# Altium schematic sheet esp-neopixel-driver_1_Main.SchDoc(esp-neopixel-driver_1_Main)
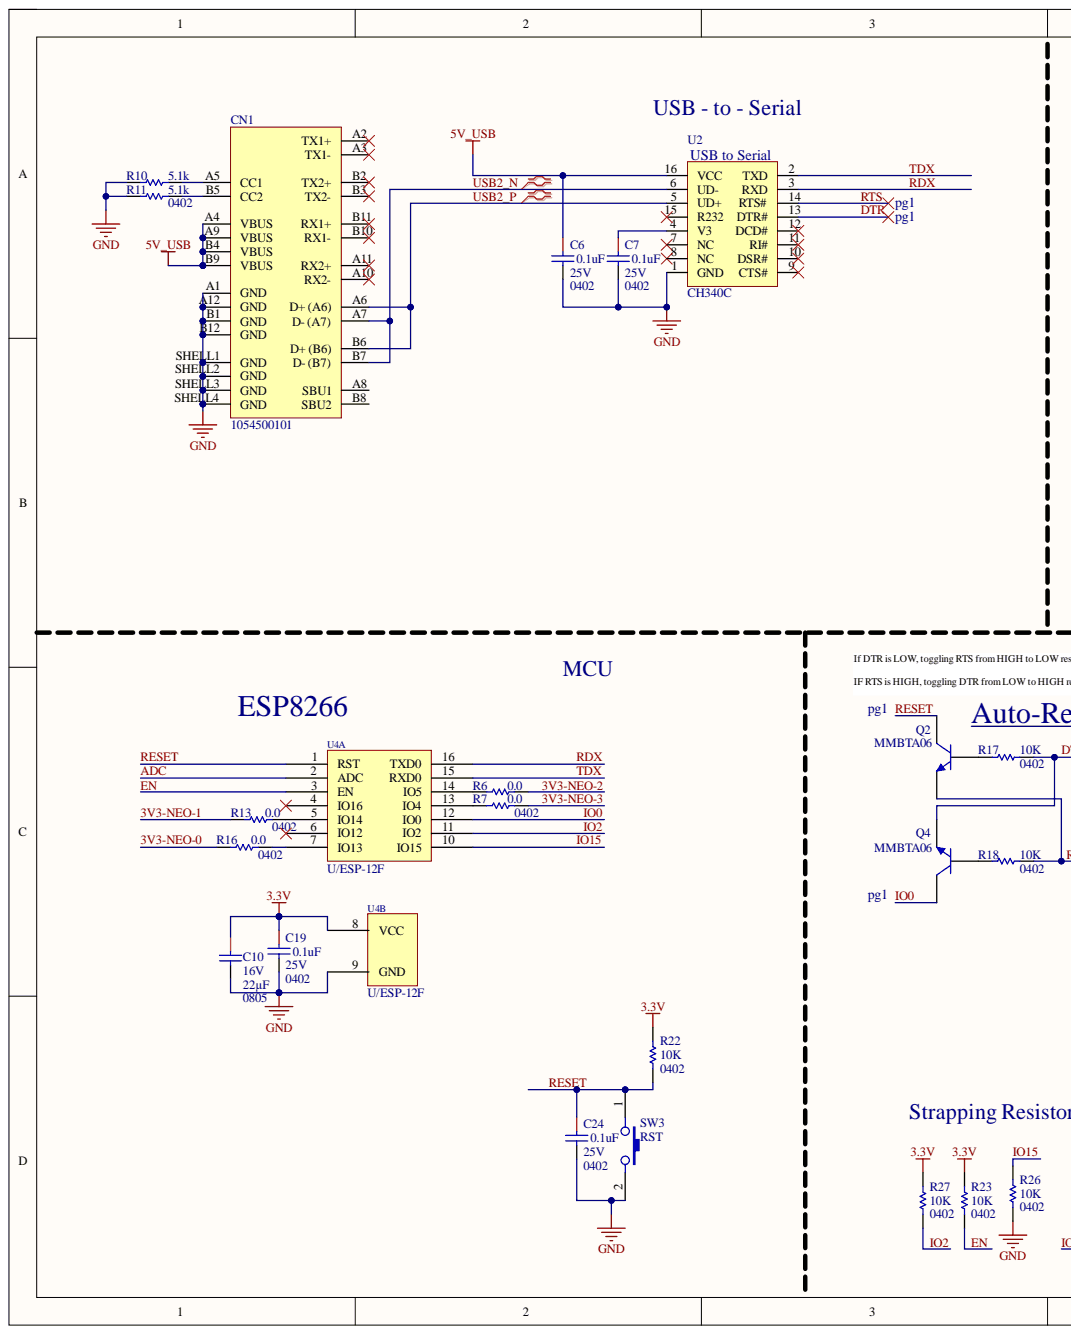
[diagram p0: esp-neopixel-driver_1_Main.SchDoc, left]
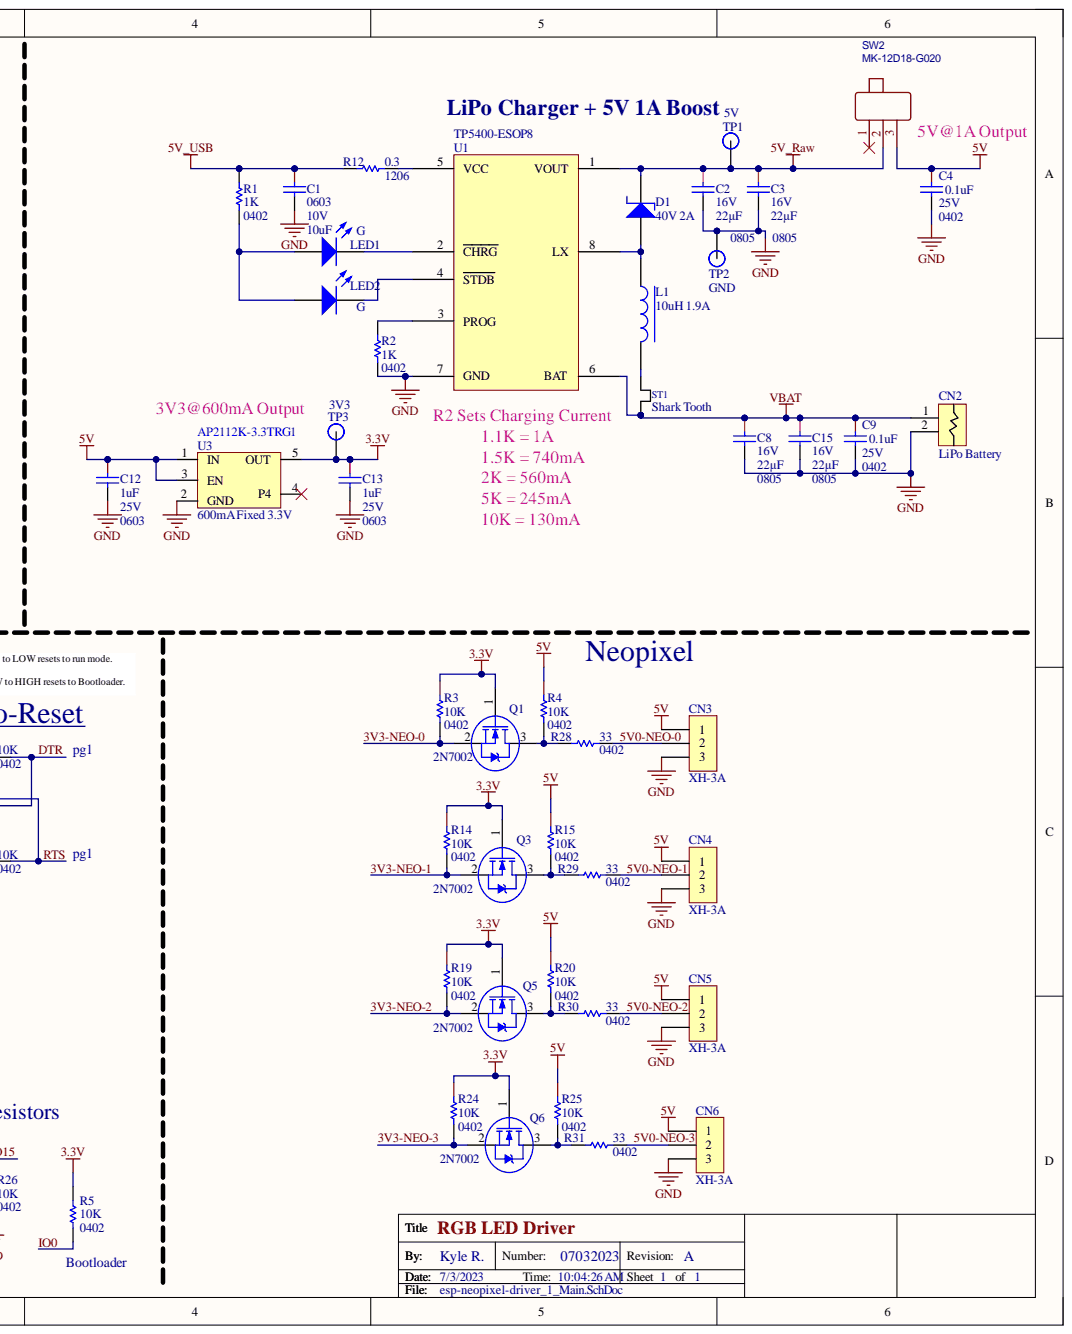
[diagram p1: esp-neopixel-driver_1_Main.SchDoc, right]

## Parts
C1  Package / Case: 0603  Voltage - Rated: 10V  [CAPC0603(1608)_N]  (hidden comment: CAP 10UF 10V 10% X5R 0603)
C2  Capacitance: 22µF  Voltage: 16V  [CAPC0805(2012)_M]  (hidden comment: 22uF)
C3  Capacitance: 22µF  Case Code (Imperial): 0805  Voltage: 16V  [CAPC0805(2012)_M]  (hidden comment: 22uF)
C4  0.1uF  Package / Case: 0402  Voltage - Rated: 25V  [CAPC0402(1005)_N]
C6  0.1uF  Package / Case: 0402  Voltage - Rated: 25V  [CAPC0402(1005)_N]
C7  0.1uF  Package / Case: 0402  Voltage - Rated: 25V  [CAPC0402(1005)_N]
C8  Capacitance: 22µF  Case Code (Imperial): 0805  Voltage: 16V  [CAPC0805(2012)_M]  (hidden comment: 22uF)
C9  0.1uF  Package / Case: 0402  Voltage - Rated: 25V  [CAPC0402(1005)_N]
C10  Capacitance: 22µF  Case Code (Imperial): 0805  Voltage: 16V  [CAPC0805(2012)_M]  (hidden comment: 22uF)
C12  1uF  Package / Case: 0603  Voltage - Rated: 25V  [CAPC0603(1608)_N]
C13  1uF  Package / Case: 0603  Voltage - Rated: 25V  [CAPC0603(1608)_N]
C15  Capacitance: 22µF  Case Code (Imperial): 0805  Voltage: 16V  [CAPC0805(2012)_M]  (hidden comment: 22uF)
C19  0.1uF  Package / Case: 0402  Voltage - Rated: 25V  [CAPC0402(1005)_N]
C24  0.1uF  Package / Case: 0402  Voltage - Rated: 25V  [CAPC0402(1005)_N]
CN1  1054500101  [USB-TYPE-C MOLEX 1054500101]
CN2  LiPo Battery  [FSR06BE-Footprint-2]
CN3  XH-3A  [XH-34]
CN4  XH-3A  [XH-34]
CN5  XH-3A  [XH-34]
CN6  XH-3A  [XH-34]
D1  40V 2A  Voltage - DC Reverse (Vr) (Max): 40V  [SOD123]
L1  10uH 1.9A  [INDP6060X29N]
LED1  G  [SM-LED-0603]
LED2  G  [SM-LED-0603]
Q1  2N7002  [SOT23]
Q2  MMBTA06  [SOT23]
Q3  2N7002  [SOT23]
Q4  MMBTA06  [SOT23]
Q5  2N7002  [SOT23]
Q6  2N7002  [SOT23]
R1  1K  Case Code (Imperial): 0402  [RESC0402(1005)_L]
R2  1K  Case Code (Imperial): 0402  [RESC0402(1005)_L]
R3  Package: 0402  Resistance: 10K  [RESC0402(1005)_N]  (hidden comment: 0402WGF1002TCE)
R4  Package: 0402  Resistance: 10K  [RESC0402(1005)_N]  (hidden comment: 0402WGF1002TCE)
R5  10K  Supplier Device Package: 0402  [RESC0402(1005)_N]
R6  0.0  [RESC0402(1005)_N]
R7  0.0  Package / Case: 0402  [RESC0402(1005)_N]
R10  5.1k  [RESC0402(1005)_L]
R11  5.1k  Supplier Device Package: 0402  [RESC0402(1005)_L]
R12  0.3  Package / Case: 1206  [RESC1206(3216)_N]
R13  0.0  Package / Case: 0402  [RESC0402(1005)_N]
R14  Package: 0402  Resistance: 10K  [RESC0402(1005)_N]  (hidden comment: 0402WGF1002TCE)
R15  Package: 0402  Resistance: 10K  [RESC0402(1005)_N]  (hidden comment: 0402WGF1002TCE)
R16  0.0  Package / Case: 0402  [RESC0402(1005)_N]
R17  10K  Supplier Device Package: 0402  [RESC0402(1005)_N]
R18  10K  Supplier Device Package: 0402  [RESC0402(1005)_N]
R19  Package: 0402  Resistance: 10K  [RESC0402(1005)_N]  (hidden comment: 0402WGF1002TCE)
R20  Package: 0402  Resistance: 10K  [RESC0402(1005)_N]  (hidden comment: 0402WGF1002TCE)
R22  10K  Supplier Device Package: 0402  [RESC0402(1005)_N]
R23  10K  Supplier Device Package: 0402  [RESC0402(1005)_N]
R24  Package: 0402  Resistance: 10K  [RESC0402(1005)_N]  (hidden comment: 0402WGF1002TCE)
R25  Package: 0402  Resistance: 10K  [RESC0402(1005)_N]  (hidden comment: 0402WGF1002TCE)
R26  10K  Supplier Device Package: 0402  [RESC0402(1005)_N]
R27  10K  Supplier Device Package: 0402  [RESC0402(1005)_N]
R28  33  Package / Case: 0402  [RESC0402(1005)_N]
R29  33  Package / Case: 0402  [RESC0402(1005)_N]
R30  33  Package / Case: 0402  [RESC0402(1005)_N]
R31  33  Package / Case: 0402  [RESC0402(1005)_N]
ST1  Shark Tooth  [SharkTooth]
SW2  MK-12D18-G020  [MK-12D18-G020]
SW3  RST  [TS-1088-AR02016]
TP1  5V  [TestPoint-Silk]  (no BOM)
TP2  GND  [TestPoint-Silk]  (no BOM)
TP3  3V3  [TestPoint-Silk]  (no BOM)
U1  TP5400-ESOP8  [SOP127P600X175_HS-9N]
U2  CH340C  [SOP-16]
U3  600mA Fixed 3.3V  [SOT95P285X130-5N]
U4  U/ESP-12F  [U/ESP-12F]  (parts A,B)

## Nets
3.3V: C10.1 C13.1 C19.1 Q1.1 Q3.1 Q5.1 Q6.1 R3.1 R5.2 R14.1 R19.1 R22.2 R23.2 R24.1 R27.2 TP3.1 U3.5 U4.8
5V_Raw: C2.1 C3.1 D1.2 SW2.2 TP1.1 U1.1
5V_USB: C1.1 C6.1 CN1.A4 CN1.A9 CN1.B4 CN1.B9 R1.2 R12.2 U2.16
GND: C1.2 C2.2 C3.2 C4.2 C6.2 C7.2 C8.2 C9.2 C10.2 C12.2 C13.2 C15.2 C19.2 C24.2 CN1.A1 CN1.A12 CN1.B1 CN1.B12 CN1.SHELL1 CN1.SHELL2 CN1.SHELL3 CN1.SHELL4 CN2.2 CN3.3 CN4.3 CN5.3 CN6.3 R2.1 R10.2 R11.2 R26.1 SW3.2 TP2.1 U1.7 U2.1 U3.2 U4.9
VBAT: C8.1 C9.1 C15.1 CN2.1 ST1.2 U1.6
3V3-NEO-0: Q1.2 R3.2 R16.2
3V3-NEO-1: Q3.2 R13.2 R14.2
3V3-NEO-2: Q5.2 R6.1 R19.2
3V3-NEO-3: Q6.2 R7.1 R24.2
5V: C4.1 C12.1 CN3.1 CN3.2 CN4.1 CN4.2 CN5.1 CN5.2 CN6.1 CN6.2 R4.1 R15.1 R20.1 R25.1 R28.1 R29.1 R30.1 R31.1 SW2.3 U3.1 U3.3  [also labelled 5V0-NEO-0, 5V0-NEO-1, 5V0-NEO-2, 5V0-NEO-3]
ADC: U4.2
DTR: Q4.2 R17.1 U2.13
EN: R23.1 U4.3
IO0: Q4.3 R5.1 U4.12
IO2: R27.1 U4.11
IO15: R26.2 U4.10
RDX: U2.3 U4.16
RESET: C24.1 Q2.3 R22.1 SW3.1 U4.1
RTS: Q2.2 R18.1 U2.14
TDX: U2.2 U4.15
USB2_N: CN1.A7 CN1.B7 U2.6
USB2_P: CN1.A6 CN1.B6 U2.5
NetC7_1: C7.1 U2.4
NetCN1_A5: CN1.A5 R10.1
NetCN1_B5: CN1.B5 R11.1
NetD1_1: D1.1 L1.1 U1.8
NetL1_2: L1.2 ST1.1
NetLED1_1: LED1.1 LED2.1 R1.1
NetLED1_2: LED1.2 U1.2
NetLED2_2: LED2.2 U1.4
NetQ1_3: Q1.3 R4.2 R28.2
NetQ2_1: Q2.1 R17.2
NetQ3_3: Q3.3 R15.2 R29.2
NetQ4_1: Q4.1 R18.2
NetQ5_3: Q5.3 R20.2 R30.2
NetQ6_3: Q6.3 R25.2 R31.2
NetR2_2: R2.2 U1.3
NetR6_2: R6.2 U4.14
NetR7_2: R7.2 U4.13
NetR12_1: R12.1 U1.5
NetR13_1: R13.1 U4.5
NetR16_1: R16.1 U4.7

Not connected: CN1 A2 A3 A8 A10 A11 B2 B3 B8 B10 B11; SW2 1; U2 7 8 9 10 11 12 15; U3 4; U4 4 6

## Library data of the parts
C1 = CAP CER 10UF 10V 10% X5R 0603: 10uF 0603; Manufacturer: Samsung Electro-Mechanics America, Inc; Manufacturer Part Number: CL10A106KP8NNNC; Applications: General Purpose; Capacitance: 10uF; Category: Capacitors; Component Kind: Standard; ComponentLink1Description: http://www.samsungsem.com/kr/front/downloadcms.do?path=/kr/support/product-search/mlcc/__icsFiles/afieldfile/2014/11/05&fileName=S_CL10A106KP8NNNC.pdf; ComponentLink1URL: http://www.samsungsem.com/kr/front/downloadcms.do?path=/kr/support/product-search/mlcc/__icsFiles/afieldfile/2014/11/05&fileName=S_CL10A106KP8NNNC.pdf; Features: -; Height - Seated (Max): -; Lead Spacing: -; Lead Style: -; Mounting Type: Surface Mount, MLCC; Operating Temperature: -55°C ~ 85°C; Packaging: Cut Tape (CT); Pricing 1: 1=0.48, 10=0.42, 25=0.3, 100=0.21, 500=0.153, 1000=0.138 (USD); Ratings: -; RoHS: RoHS Compliant; Size / Dimension: 0.063" L x 0.031" W (1.60mm x 0.80mm); Stock 1: 157585; Supplier 1: Digi-Key; Supplier Part Number 1: 1276-1192-1-ND; Temperature Coefficient: X5R; Thickness (Max): 0.035" (0.90mm); Tolerance: ±10%; Library Name: Kyle-Passive.IntLib
C2 C3 C8 C10 C15 = CAP CER 22UF 16V X5R 0805: 22uF 0805; Manufacturer: Murata; Manufacturer Part Number: GRM219R61C226ME15L; Dielectric: X5R; Dielectric Material: Ceramic; Dissipation Factor: 0.035%; Tolerance: 20%; Case Code (Metric): 2012; Case/Package: 0805; Category: Capacitors; Component Kind: Standard; ComponentLink1Description: http://www.farnell.com/datasheets/2079176.pdf; ComponentLink1URL: http://www.farnell.com/datasheets/2079176.pdf; ComponentLink2Description: http://psearch.en.murata.com/capacitor/product/GRM219R61C226ME15%23.pdf; ComponentLink2URL: http://psearch.en.murata.com/capacitor/product/GRM219R61C226ME15%23.pdf; ComponentLink3Description: http://search.murata.co.jp/Ceramy/image/img/A01X/partnumbering_e_01.pdf; ComponentLink3URL: http://search.murata.co.jp/Ceramy/image/img/A01X/partnumbering_e_01.pdf; ComponentLink4Description: http://www.mouser.com/ds/2/281/c02e-2905.pdf; ComponentLink4URL: http://www.mouser.com/ds/2/281/c02e-2905.pdf; Depth: 1.25mm; Height: 0.85mm; Lead Free: Lead Free; Length: 2mm; Max Operating Temperature: 85°C; Min Operating Temperature: -55°C; Mount: Surface Mount; Package / Case: 0805; Package Quantity: 3000; Packaging: Tape and Reel; Pricing 1: 1=0.51, 10=0.359, 100=0.2364, 500=0.1751, 1000=0.14884 (USD); REACH SVHC: No SVHC; Stock 1: 483884; Supplier 1: Digi-Key; Supplier Part Number 1: 490-9952-1-ND; Termination: SMD/SMT; Thickness: 0.039inch; Voltage Rating: 16V; Voltage Rating (DC): 16V; Weight: 0.000335oz; Width: 0.049inch; Library Name: Kyle-Passive.IntLib
  C2: Case Code (Imperial): 0805
C4 C6 C7 C9 C19 C24 = CAP CER 0.1UF 25V 10% X5R 0402: 0.1uF 0402 25V; Manufacturer: Taiyo Yuden; Manufacturer Part Number: TMK105BJ104KV-F; Notification: Current market demand for these product types have resulted in fluctuating and extending lead times. Lead times may differ.; Temperature Coefficient: X5R; Tolerance: ±10%; Applications: General Purpose; Category: Capacitors; Component Kind: Standard; ComponentLink1Description: http://ds.yuden.co.jp/TYCOMPAS/ut/detail.do?productNo=TMK105BJ104KV-F&fileName=TMK105BJ104KV-F_SS&mode=specSheetDownload; ComponentLink1URL: http://ds.yuden.co.jp/TYCOMPAS/ut/detail.do?productNo=TMK105BJ104KV-F&fileName=TMK105BJ104KV-F_SS&mode=specSheetDownload; Features: -; Height - Seated (Max): -; Lead Spacing: -; Lead Style: -; Mounting Type: Surface Mount, MLCC; Operating Temperature: -55°C ~ 85°C; Packaging: Cut Tape (CT); Part Status: Active; Pricing 1: 1=0.1, 10=0.043, 100=0.019, 500=0.0136, 1000=0.01069, 2500=0.00972, 5000=0.00894 (USD); Ratings: -; RoHS: RoHS Compliant; Size / Dimension: 0.039" L x 0.020" W (1.00mm x 0.50mm); Stock 1: 5172635; Supplier 1: Digi-Key; Supplier Part Number 1: 587-1456-1-ND; Thickness (Max): 0.022" (0.55mm); Library Name: Kyle-Passive.IntLib
C12 C13 = CAP CER 1UF 25V 10% X7R 0603: 1uF 0603 25V; Manufacturer: TDK Corporation; Manufacturer Part Number: CGA3E1X7R1E105K080AC; Temperature Coefficient: X7R; Tolerance: ±10%; Applications: Automotive; Category: Capacitors; Component Kind: Standard; ComponentLink1Description: https://product.tdk.com/info/en/catalog/datasheets/mlcc_automotive_general_en.pdf; ComponentLink1URL: https://product.tdk.com/info/en/catalog/datasheets/mlcc_automotive_general_en.pdf; Failure Rate: -; Features: -; Height - Seated (Max): -; Lead Spacing: -; Lead Style: -; Mounting Type: Surface Mount, MLCC; Operating Temperature: -55°C ~ 125°C; Packaging: Cut Tape (CT); Part Status: Active; Pricing 1: 1=0.2, 10=0.135, 100=0.0686, 500=0.04696, 1000=0.04154 (USD); Ratings: AEC-Q200; RoHS: RoHS Compliant; Size / Dimension: 0.063" L x 0.032" W (1.60mm x 0.80mm); Stock 1: 360610; Supplier 1: Digi-Key; Supplier Part Number 1: 445-6931-1-ND; Thickness (Max): 0.035" (0.90mm); Library Name: Kyle-Passive.IntLib
CN1 = USB-C RA Molex: CONN RCPT USB C 3.1 SMT R/A; Manufacturer: Molex, LLC; Connector Type: USB - C; Current Rating: 0.25A, 1A, 5A; Gender: Receptacle; Material Flammability Rating: UL94 V-0; Mating Cycles: 10000; Number of Contacts: 24; Shielding: Shielded; Specifications: USB 3.1 (USB 3.1 Gen 2, Superspeed+); Voltage - Rated: 30VAC/DC; Category: Connectors, Interconnects; Component Kind: Standard; ComponentLink1Description: http://www.molex.com/pdm_docs/sd/1054500101_sd.pdf; ComponentLink1URL: http://www.molex.com/pdm_docs/sd/1054500101_sd.pdf; Contact Finish: Gold; Features: -; Ingress Protection: -; Insulation Color: Black; Manufacturer Part Number: 1054500101; Mounting Feature: Horizontal; Mounting Type: Surface Mount, Right Angle; Through Hole; Operating Temperature: -30°C ~ 85°C; Packaging: Tape & Reel (TR); Part Status: Active; Pricing 1: 1300=1.1577, 2600=1.08052, 6500=1.02649, 9100=1.00334 (USD); RoHS: RoHS Compliant; Shell/Shielding Material: Steel, Stainless; Stock 1: 2600; Supplier 1: Digi-Key; Supplier Part Number 1: WM12856TR-ND; Termination: Solder; Library Name: Kyle-Connectors.IntLib
CN2 = CMP-001-1532-04: Force Sensors & Load Cells 14.7mm Round Solder Tab; Manufacturer: Ohmite; Manufacturer Product Number: FSR06BE; Component Kind: Standard; Library Name: OLogic Nexus Vault
D1 = SS24FL: DIODE SCHOTTKY 40V 2A SOD123F; Manufacturer: Fairchild/ON Semiconductor; Current - Reverse Leakage @ Vr: 100µA @ 40V; Speed: Fast Recovery =< 500ns, > 200mA (Io); Voltage - Forward (Vf) (Max) @ If: 550mV @ 2A; Capacitance @ Vr, F: -; Category: Discrete Semiconductor Products; Component Kind: Standard; ComponentLink1Description: https://www.fairchildsemi.com/datasheets/SS/SS24FL.pdf; ComponentLink1URL: https://www.fairchildsemi.com/datasheets/SS/SS24FL.pdf; Current - Average Rectified (Io): 2A; Diode Type: Schottky; Manufacturer Part Number: SS24FL; Mounting Type: Surface Mount; Operating Temperature - Junction: -55°C ~ 125°C; Package / Case: SOD-123F; Packaging: Cut Tape (CT); Part Status: Active; Pricing 1: 1=0.34, 10=0.255, 100=0.1446, 500=0.09572, 1000=0.07339 (USD); RoHS: RoHS Compliant; Stock 1: 4748; Supplier 1: Digi-Key; Supplier Device Package: SOD-123F; Supplier Part Number 1: SS24FLCT-ND; Library Name: Kyle-Diode-Opto-LED.IntLib
L1 = NRSE6028-100M: 1.9A 10uH ±20% SMD Power Inductors ROHS; Manufacturer: KOHERelec; DC Resistance (DCR): 33 mOhm Max; Saturation: 2.05A; Shielding: Shielded; Type: Molded; Category: Inductors, Coils, Chokes; Component Kind: Standard; Height - Seated (Max): 0.047" (1.20mm); JLCPCB Part #: C842750; Manufacturer Part Number: NRSE6028-100M; Material - Core: -; Mounting Type: Surface Mount; Operating Temperature: -55°C ~ 125°C; Package / Case: Nonstandard; Packaging: Cut Tape (CT); Part Status: Active; Pricing 1: 1=1.45, 10=1.346, 100=0.9729, 500=0.7452, 1000=0.6624 (USD); Q @ Freq: -; Ratings: -; RoHS: RoHS Compliant; Size / Dimension: 0.144" L x 0.118" W (3.65mm x 3.00mm); Supplier Device Package: -; Tolerance: ±20%; Library Name: Kyle-Passive.IntLib
LED1 = LTST-C191KGKT: LED GREEN CLEAR THIN 0603 SMD; Manufacturer: Lite-On Inc; Current - Test: 20mA; Lens Style/Size: Rectangle with Flat Top, 1.1mm x 0.8mm; Millicandela Rating: 35mcd; Viewing Angle: 130°; Voltage - Forward (Vf) (Typ): 2V; Wavelength - Dominant: 571nm; Wavelength - Peak: 574nm; Category: Optoelectronics; Color: Green; Component Kind: Standard; ComponentLink1Description: http://optoelectronics.liteon.com/upload/download/DS22-2000-228/S_110_LTST-C191KGKT.pdf; ComponentLink1URL: http://optoelectronics.liteon.com/upload/download/DS22-2000-228/S_110_LTST-C191KGKT.pdf; Height: 0.55mm; Lens Type: Clear; Luminous Flux @ Current - Test: -; Manufacturer Part Number: LTST-C191KGKT; Mounting Type: Surface Mount; Package / Case: 0603 (1608 Metric); Packaging: Cut Tape (CT); Pricing 1: 1=0.3, 10=0.238, 100=0.1296, 250=0.0864, 500=0.07344, 1000=0.05616, 2500=0.05076 (USD); RoHS: RoHS Compliant; Size / Dimension: 1.60mm L x 0.80mm W; Stock 1: 84829; Supplier 1: Digi-Key; Supplier Part Number 1: 160-1446-1-ND; Library Name: Kyle-Diode-Opto-LED.IntLib
LED2 = 0603 LED: 0603 SMD LED; PN: P11500CT-ND; Vendor: Digikey; Component Kind: Standard; Library Name: Kyle-Diode-Opto-LED.IntLib
Q1 Q3 Q5 Q6 = 2N7002P: MOSFET N-CH 60V 0.36A SOT-23; Manufacturer: Diodes Incorporated; Manufacturer Part Number: 2N7002K-7; Current - Continuous Drain (Id) @ 25°C: 380mA (Ta); Drive Voltage (Max Rds On,  Min Rds On): 5V, 10V; FET Type: N-Channel; Power Dissipation (Max): 370mW (Ta); Rds On (Max) @ Id, Vgs: 2 Ohm @ 500mA, 10V; Vgs (Max): ±20V; Vgs(th) (Max) @ Id: 2.5V @ 1mA; Category: Discrete Semiconductor Products; Component Kind: Standard; ComponentLink1Description: https://www.diodes.com/assets/Datasheets/ds30896.pdf; ComponentLink1URL: https://www.diodes.com/assets/Datasheets/ds30896.pdf; FET Feature: -; Mounting Type: Surface Mount; Operating Temperature: -55°C ~ 150°C (TJ); Package / Case: TO-236-3, SC-59, SOT-23-3; Packaging: Cut Tape (CT); Part Status: Active; Pricing 1: 1=0.25, 10=0.23, 100=0.1286, 500=0.06888, 1000=0.04683 (USD); RoHS: RoHS Compliant; Stock 1: 255096; Supplier 1: Digi-Key; Supplier Device Package: SOT-23-3; Supplier Part Number 1: 2N7002K-7DICT-ND; Technology: MOSFET (Metal Oxide); Library Name: Kyle-Trans-Drivers.IntLib
Q2 Q4 = MMBTA06: TRANS NPN 80V 0.5A SOT-23; Manufacturer: ON Semiconductor; Manufacturer Part Number: MMBTA06LT3G; Current - Collector Cutoff (Max): 100nA; DC Current Gain (hFE) (Min) @ Ic, Vce: 100 @ 100mA, 1V; Frequency - Transition: 100MHz; Vce Saturation (Max) @ Ib, Ic: 250mV @ 10mA, 100mA; Base Part Number: MMBTA06; Category: Discrete Semiconductor Products; Component Kind: Standard; ComponentLink1Description: http://www.onsemi.com/pub/Collateral/MMBTA05LT1-D.PDF; ComponentLink1URL: http://www.onsemi.com/pub/Collateral/MMBTA05LT1-D.PDF; Mounting Type: Surface Mount; Operating Temperature: -55°C ~ 150°C (TJ); Package / Case: TO-236-3, SC-59, SOT-23-3; Packaging: Cut Tape (CT); Part Status: Active; Pricing 1: 1=0.21, 10=0.196, 100=0.1067, 500=0.06566, 1000=0.04476 (USD); RoHS: RoHS Compliant; Stock 1: 16604; Supplier 1: Digi-Key; Supplier Device Package: SOT-23-3 (TO-236); Supplier Part Number 1: MMBTA06LT3GOSCT-ND; Transistor Type: NPN; Library Name: Kyle-Trans-Drivers.IntLib
R1 R2 = RES 1K OHM 1% 1/16W 0402: 1K 0402; Manufacturer: Yageo; Manufacturer Part Number: RC0402FR-071KL; Power Rating: 62.5mW; Temperature Coefficient: 100ppm/°C; Tolerance: 1%; Voltage Rating: 50V; Case Code (Metric): 1005; Case/Package: 0402; Category: Resistors; Component Kind: Standard; ComponentLink1Description: https://datasheet.ciiva.com/2453/pyu-r-int-thick-7-2453361.pdf; ComponentLink1URL: https://datasheet.ciiva.com/2453/pyu-r-int-thick-7-2453361.pdf; ComponentLink2Description: https://datasheet.ciiva.com/2453/pyu-r-int-thick-7-2453362.pdf; ComponentLink2URL: https://datasheet.ciiva.com/2453/pyu-r-int-thick-7-2453362.pdf; ComponentLink3Description: https://datasheet.ciiva.com/2453/pyu-r-int-thick-7-2453363.pdf; ComponentLink3URL: https://datasheet.ciiva.com/2453/pyu-r-int-thick-7-2453363.pdf; ComponentLink4Description: https://datasheet.ciiva.com/22747/1018594-22747509.pdf; ComponentLink4URL: https://datasheet.ciiva.com/22747/1018594-22747509.pdf; ComponentLink5Description: https://datasheet.ciiva.com/15189/getdatasheetpartid-323696-15189334.pdf; ComponentLink5URL: https://datasheet.ciiva.com/15189/getdatasheetpartid-323696-15189334.pdf; ComponentLink6Description: https://datasheet.ciiva.com/16740/pyu-rc0402-51-rohs-l-6-r-16740567.pdf; ComponentLink6URL: https://datasheet.ciiva.com/16740/pyu-rc0402-51-rohs-l-6-r-16740567.pdf; ComponentLink7Description: https://datasheet.ciiva.com/12667/pyu-rc0402-51-rohs-l-6-r-12667930.pdf; ComponentLink7URL: https://datasheet.ciiva.com/12667/pyu-rc0402-51-rohs-l-6-r-12667930.pdf; ComponentLink8Description: https://datasheet.ciiva.com/11819/0900766b80c66297-11819452.pdf; ComponentLink8URL: https://datasheet.ciiva.com/11819/0900766b80c66297-11819452.pdf; ComponentLink9Description: https://datasheet.ciiva.com/11819/0900766b80c66297-11819477.pdf; ComponentLink9URL: https://datasheet.ciiva.com/11819/0900766b80c66297-11819477.pdf; ComponentLink10Description: https://datasheet.ciiva.com/12666/pyu-rc0402-51-rohs-l-6-r-12666741.pdf; ComponentLink10URL: https://datasheet.ciiva.com/12666/pyu-rc0402-51-rohs-l-6-r-12666741.pdf; ComponentLink11Description: https://datasheet.ciiva.com/17063/pyu-rc0402-51-rohs-l-6-r-17063193.pdf; ComponentLink11URL: https://datasheet.ciiva.com/17063/pyu-rc0402-51-rohs-l-6-r-17063193.pdf; ComponentLink12Description: https://datasheet.ciiva.com/22747/1018594-22747513.pdf; ComponentLink12URL: https://datasheet.ciiva.com/22747/1018594-22747513.pdf; ComponentLink13Description: https://datasheet.ciiva.com/7849/1018594-7849869.pdf; ComponentLink13URL: https://datasheet.ciiva.com/7849/1018594-7849869.pdf; ComponentLink14Description: https://datasheet.ciiva.com/7983/1018594-7983502.pdf; ComponentLink14URL: https://datasheet.ciiva.com/7983/1018594-7983502.pdf; ComponentLink15Description: https://datasheet.ciiva.com/7849/1018594-7849871.pdf; ComponentLink15URL: https://datasheet.ciiva.com/7849/1018594-7849871.pdf; Composition: Thick Film; Depth: 0.5mm; Height: 0.32mm; Lead Free: Lead Free; Length: 1mm; Max Operating Temperature: 155°C; Military Standard: Not; Min Operating Temperature: -55°C; Number of Pins: 2; Package / Case: 0402; Packaging: Tape and Reel; Pricing 1: 1=0.1, 10=0.014, 100=0.0056, 1000=0.0025, 2500=0.0022, 5000=0.0018 (USD); REACH SVHC: Unknown; Resistance: 1K; Stock 1: 21888659; Supplier 1: Digi-Key; Supplier Part Number 1: 311-1.00KLRCT-ND; Termination: SMD/SMT; Voltage Rating (DC): 50V; Width: 0.5mm; Library Name: Kyle-Passive.IntLib
R3 R4 R14 R15 R19 R20 R24 R25 = 10k_0402: 10KOHMS ±1% 1/10W 0402; Component Kind: Standard; Library Name: JLCPCB_Resistors.IntLib; Manufacturer Part Number 1: 0402WGF1002TCE; Supplier 1: LCSC; Supplier Part Number 1: C25744
R5 R17 R18 R22 R23 R26 R27 = RES 10K OHM 1% 1/16W 0402: 10K 0402; Manufacturer: Samsung Electro-Mechanics; Manufacturer Part Number: RC1005F103CS; Power (Watts): 0.063W, 1/16W; Temperature Coefficient: ±100ppm/°C; Tolerance: ±1%; Category: Resistors; Component Kind: Standard; ComponentLink1Description: http://www.samsungsem.com/kr/support/product-search/chip-resistor/RC1005F103CS.jsp; ComponentLink1URL: http://www.samsungsem.com/kr/support/product-search/chip-resistor/RC1005F103CS.jsp; Composition: Thick Film; Failure Rate: -; Features: Moisture Resistant; Height - Seated (Max): 0.016" (0.40mm); Operating Temperature: -55°C ~ 155°C; Package / Case: 0402 (1005 Metric); Packaging: Cut Tape (CT); Part Status: Active; Pricing 1: 1=0.1, 10=0.021, 100=0.0086, 1000=0.00385, 2500=0.00334, 5000=0.00276 (USD); RoHS: RoHS Compliant; Size / Dimension: 0.039" L x 0.020" W (1.00mm x 0.50mm); Stock 1: 25834562; Supplier 1: Digi-Key; Supplier Part Number 1: 1276-3431-1-ND; Library Name: Kyle-Passive.IntLib
R6 R7 R13 R16 = RES 0.0 OHM 1/16W 0402: 0.0 0402; Manufacturer: Vishay Dale; Manufacturer Part Number: RCG04020000Z0ED; Power (Watts): 0.063W, 1/16W; Tolerance: Jumper; Category: Resistors; Component Kind: Standard; ComponentLink1Description: http://www.vishay.com/docs/20047/rcge3.pdf; ComponentLink1URL: http://www.vishay.com/docs/20047/rcge3.pdf; Composition: Thick Film; Failure Rate: -; Features: -; Height - Seated (Max): 0.016" (0.40mm); Operating Temperature: -55°C ~ 155°C; Packaging: Cut Tape (CT); Part Status: Active; Pricing 1: 1=0.1, 10=0.074, 100=0.03, 1000=0.01347, 2500=0.01169, 5000=0.00966 (USD); Resistance (Ohms): 0.0; RoHS: RoHS Compliant; Size / Dimension: 0.039" L x 0.020" W (1.00mm x 0.50mm); Stock 1: 8215; Supplier 1: Digi-Key; Supplier Device Package: 0402; Supplier Part Number 1: 541-1887-1-ND; Temperature Coefficient: -; Library Name: Kyle-Passive.IntLib
  R6: Package / Case: 0402
R10 R11 = RES 5.1K OHM 1% 1/10W 0402: 5.1k 0402 1%; Manufacturer: Panasonic Electronic Components; Manufacturer Part Number: ERJ-2RKF5101X; Power (Watts): 0.1W, 1/10W; Temperature Coefficient: ±100ppm/°C; Category: Resistors; Component Kind: Standard; ComponentLink1Description: https://industrial.panasonic.com/cdbs/www-data/pdf/RDA0000/AOA0000C304.pdf; ComponentLink1URL: https://industrial.panasonic.com/cdbs/www-data/pdf/RDA0000/AOA0000C304.pdf; Composition: Thick Film; Failure Rate: -; Features: Automotive AEC-Q200; Height - Seated (Max): 0.016" (0.40mm); Operating Temperature: -55°C ~ 155°C; Package / Case: 0402 (1005 Metric); Packaging: Cut Tape (CT); Part Status: Active; Pricing 1: 1=0.1, 10=0.043, 100=0.0176, 1000=0.00791, 2500=0.00686, 5000=0.00567 (USD); RoHS: RoHS Compliant; Size / Dimension: 0.039" L x 0.020" W (1.00mm x 0.50mm); Stock 1: 827411; Supplier 1: Digi-Key; Supplier Part Number 1: P5.10KLCT-ND; Tolerance: ±1%; Library Name: Kyle-Passive.IntLib
  R10: Supplier Device Package: 0402
R12 = RES 0.3 OHM 1% 1/2W 1206: 0.25 1206; Manufacturer: Yago; Manufacturer Part Number: RL1206FR-7W0R3L; Power (Watts): 0.5W, 1/2W; Temperature Coefficient: ±100ppm/°C; Tolerance: ±1%; Category: Resistors; Component Kind: Standard; ComponentLink1Description: http://www.seielect.com/catalog/SEI-CSR_CSRN.pdf; ComponentLink1URL: http://www.seielect.com/catalog/SEI-CSR_CSRN.pdf; Composition: Thick Film; Features: Current Sense; Height: 0.026" (0.65mm); Number of Terminations: 2; Operating Temperature: -55°C ~ 155°C; Packaging: Cut Tape (CT); Pricing 1: 1=0.63, 10=0.428, 50=0.2588, 100=0.2138, 250=0.16876, 500=0.144 (USD); Resistance (Ohms): 0.3; RoHS: RoHS Compliant; Size / Dimension: 0.120" L x 0.061" W (3.05mm x 1.55mm); Stock 1: 51905; Supplier 1: Digi-Key; Supplier Device Package: 1206; Supplier Part Number 1: CSR1206FKR250CT-ND; Library Name: Kyle-Passive.IntLib
R28-R31 = RES 33 OHM 1% 1/16W 0402: 33 0402; Manufacturer: Yageo; Manufacturer Part Number: RC0402FR-0733RL; Power (Watts): 0.063W, 1/16W; Temperature Coefficient: ±100ppm/°C; Tolerance: ±1%; Category: Resistors; Component Kind: Standard; ComponentLink1Description: http://www.yageo.com/documents/recent/PYu-RC_Group_51_RoHS_L_7.pdf; ComponentLink1URL: http://www.yageo.com/documents/recent/PYu-RC_Group_51_RoHS_L_7.pdf; Composition: Thick Film; Failure Rate: -; Features: Moisture Resistant; Height - Seated (Max): 0.016" (0.40mm); Operating Temperature: -55°C ~ 155°C; Packaging: Cut Tape (CT); Part Status: Active; Pricing 1: 1=0.1, 10=0.014, 100=0.0056, 1000=0.00253, 2500=0.0022, 5000=0.00181 (USD); Resistance (Ohms): 33; RoHS: RoHS Compliant; Size / Dimension: 0.039" L x 0.020" W (1.00mm x 0.50mm); Stock 1: 2796942; Supplier 1: Digi-Key; Supplier Device Package: 0402; Supplier Part Number 1: 311-33.0LRCT-ND; Library Name: Kyle-Passive.IntLib
ST1 = Shark Tooth: Sharks Tooth Small; Component Kind: Standard; Library Name: Kyle_Lib_04-20-19.IntLib
SW2 = MK-12D18-G020: SPST Slide Switch; BOM_Manufacturer: G-Switch(品赞); Contributor: lcsc; DC Voltage: 12V 0.5A/6V 1.0A; BOM_JLCPCB Part Class: Extended Part; BOM_Manufacturer Part: MK-12D18-G020; BOM_Supplier: LCSC; BOM_Supplier Part: C3019727; Component Kind: Standard; link: https://atta.szlcsc.com/upload/public/pdf/source/20201201/C963202_825FB742C5BA766BED52E1DDA96DE5A3.pdf; spicePre: S; spiceSymbolName: MK-12D18-G020; Library Name: Kyle-Switches.IntLib
SW3 = TS-1088-AR02016: SMD,3x4x2mm Tactile Switches ROHS; Component Kind: Standard; LCSC Part #: C520860; Library Name: JLCPCB-Switch.IntLib
TP1-TP3 = TestPoint - Current: Test Point; Library Name: Kyle-Misc.IntLib
U1 = CMP-001-1694-05: Single-cell Li-ion battery charging 1A And boost 5V Output 1A Controller; Manufacturer: TOPPOWER(Nanjing Extension Microelectronics); Mfr. Part #: TP5400; Component Kind: Standard; LCSC Part Number: C24154; Library Name: OLogic Nexus Vault
U2 = CH340C: USB to Serial UART; Component Kind: Standard; ComponentLink1Description: Sparkfun Demo Board; ComponentLink1URL: https://www.sparkfun.com/products/15096; ComponentLink2Description: LCSC Part Link; ComponentLink2URL: https://lcsc.com/product-detail/USB_CH340C_C84681.html; Library Name: Kyle_Lib_04-20-19.SchLib
U3 = AP2112K-3.3TRG1: 65dB@(100Hz,1kHz) 600mA Fixed 3.3V~3.3V Positive 6V SOT-25-5 Linear Voltage Regulators (LDO) ROHS; Component Kind: Standard; MFR.Part #: AP2112K-3.3TRG1; Package: SOT-25-5; Library Name: Kyle-Reg-Convert.IntLib
CN3-CN6 = XH-3A: Component Kind: Standard; Library Name: Kyle-Connectors.IntLib
U4 = U/ESP-12F: Component Kind: Standard; Library Name: Kyle_Lib_04-20-19.IntLib
